# Altium schematic sheet main.SchDoc(main)
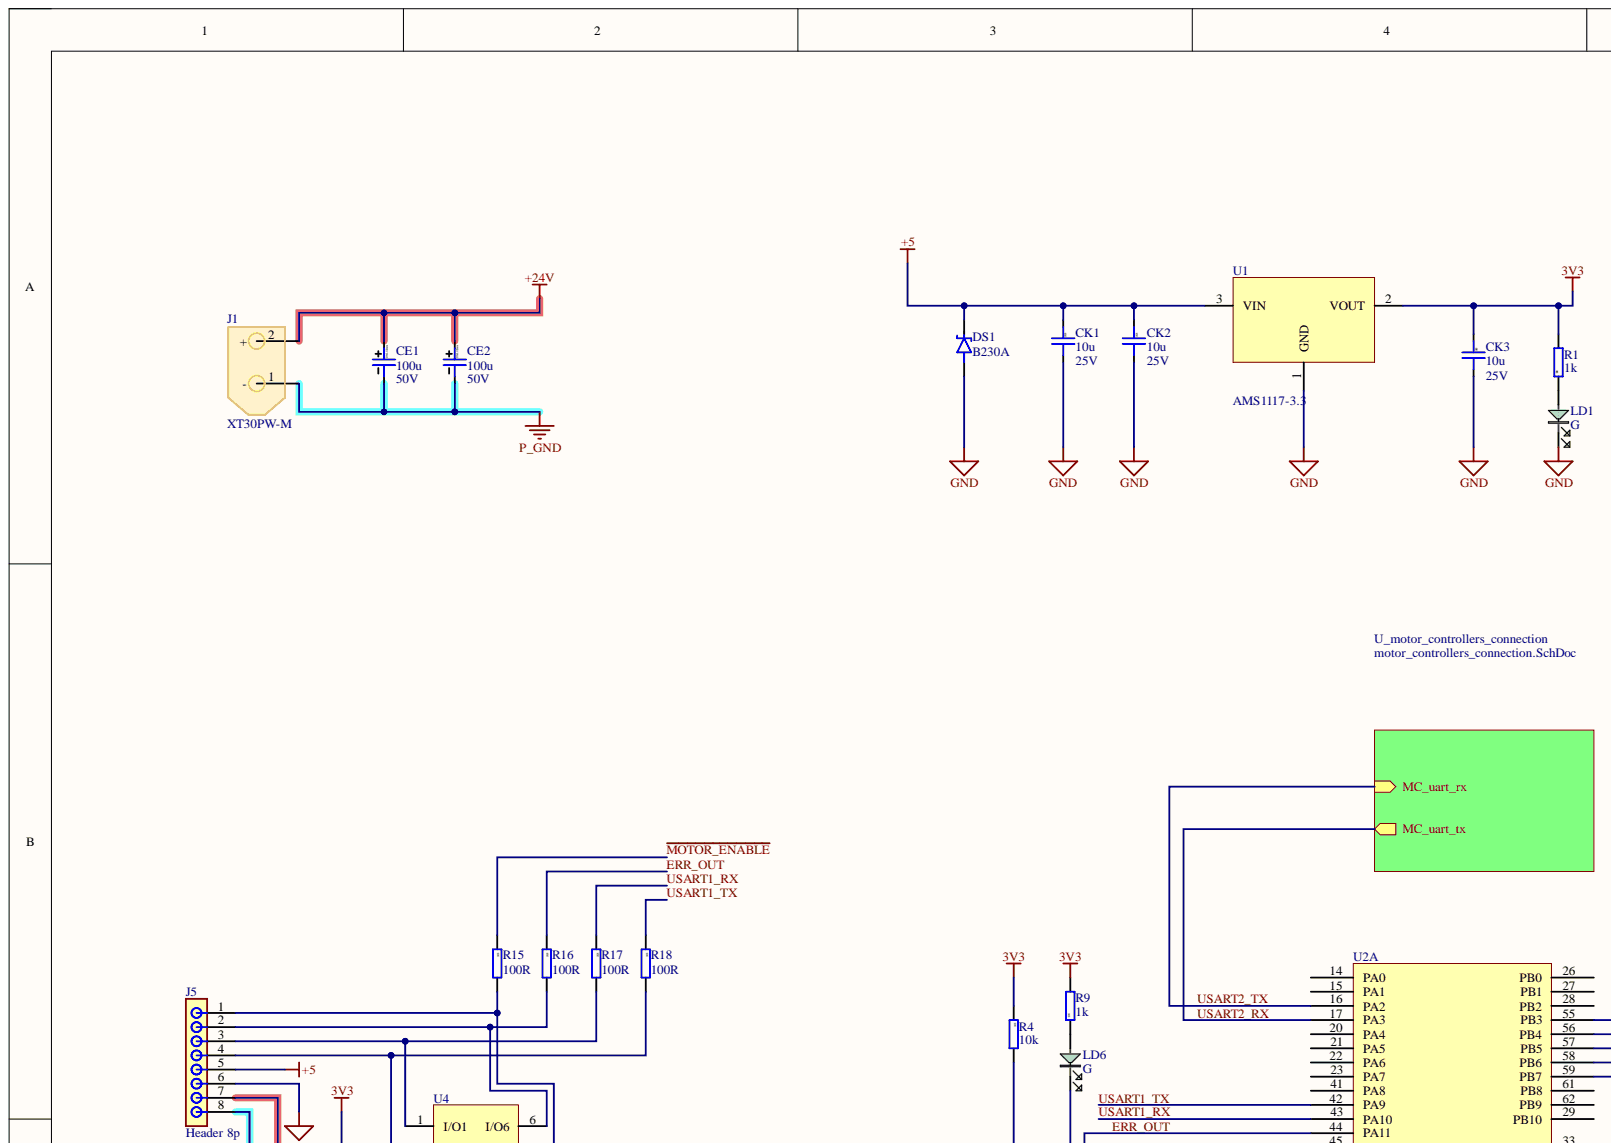
[diagram p0: main.SchDoc, top left]
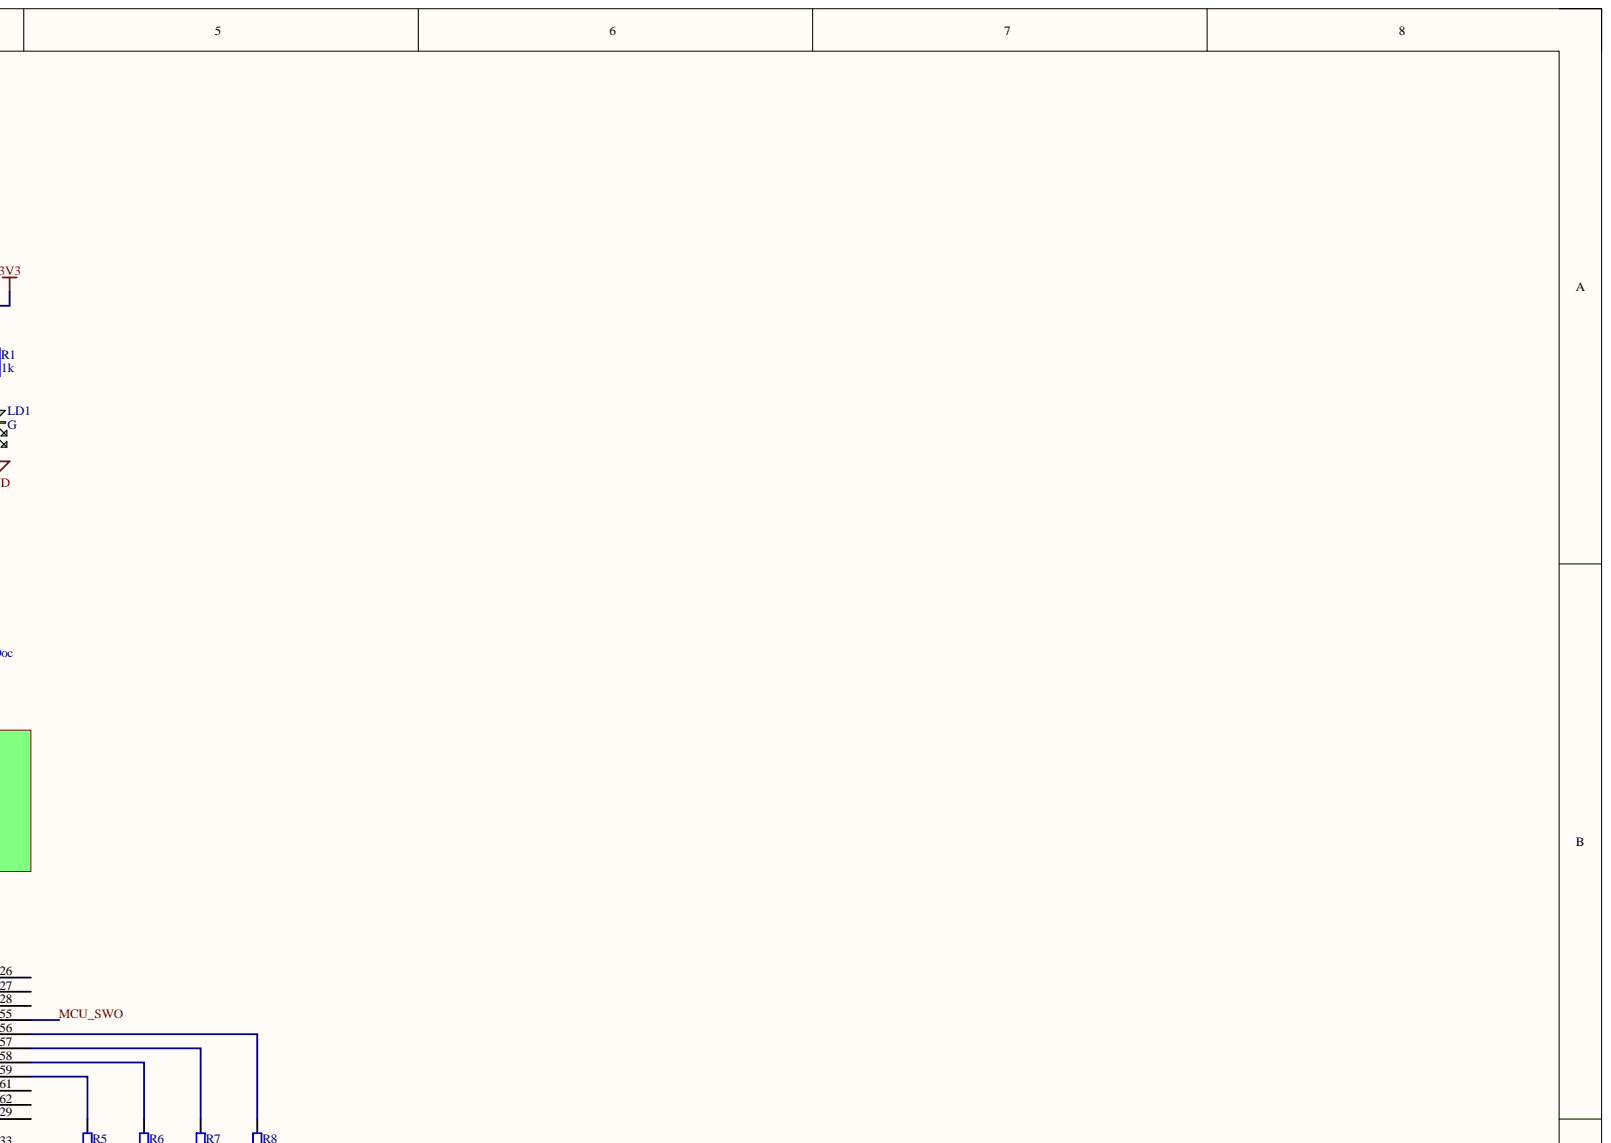
[diagram p1: main.SchDoc, top right]
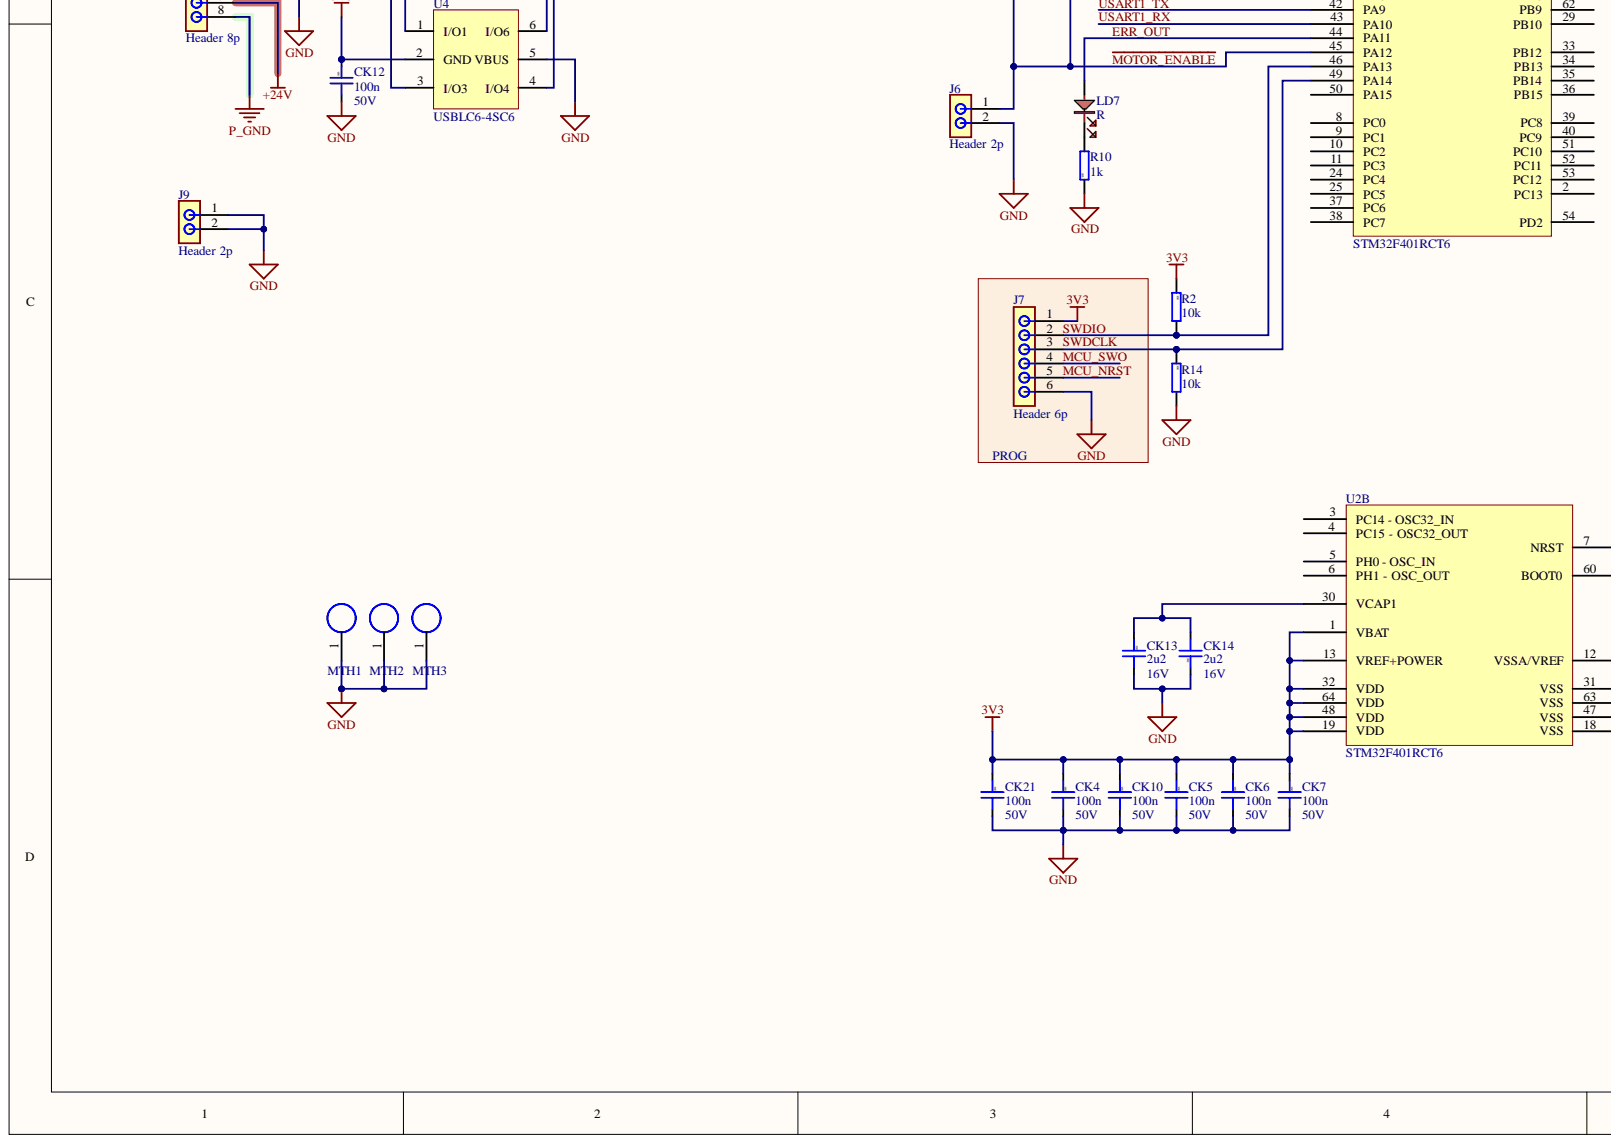
[diagram p2: main.SchDoc, bottom left]
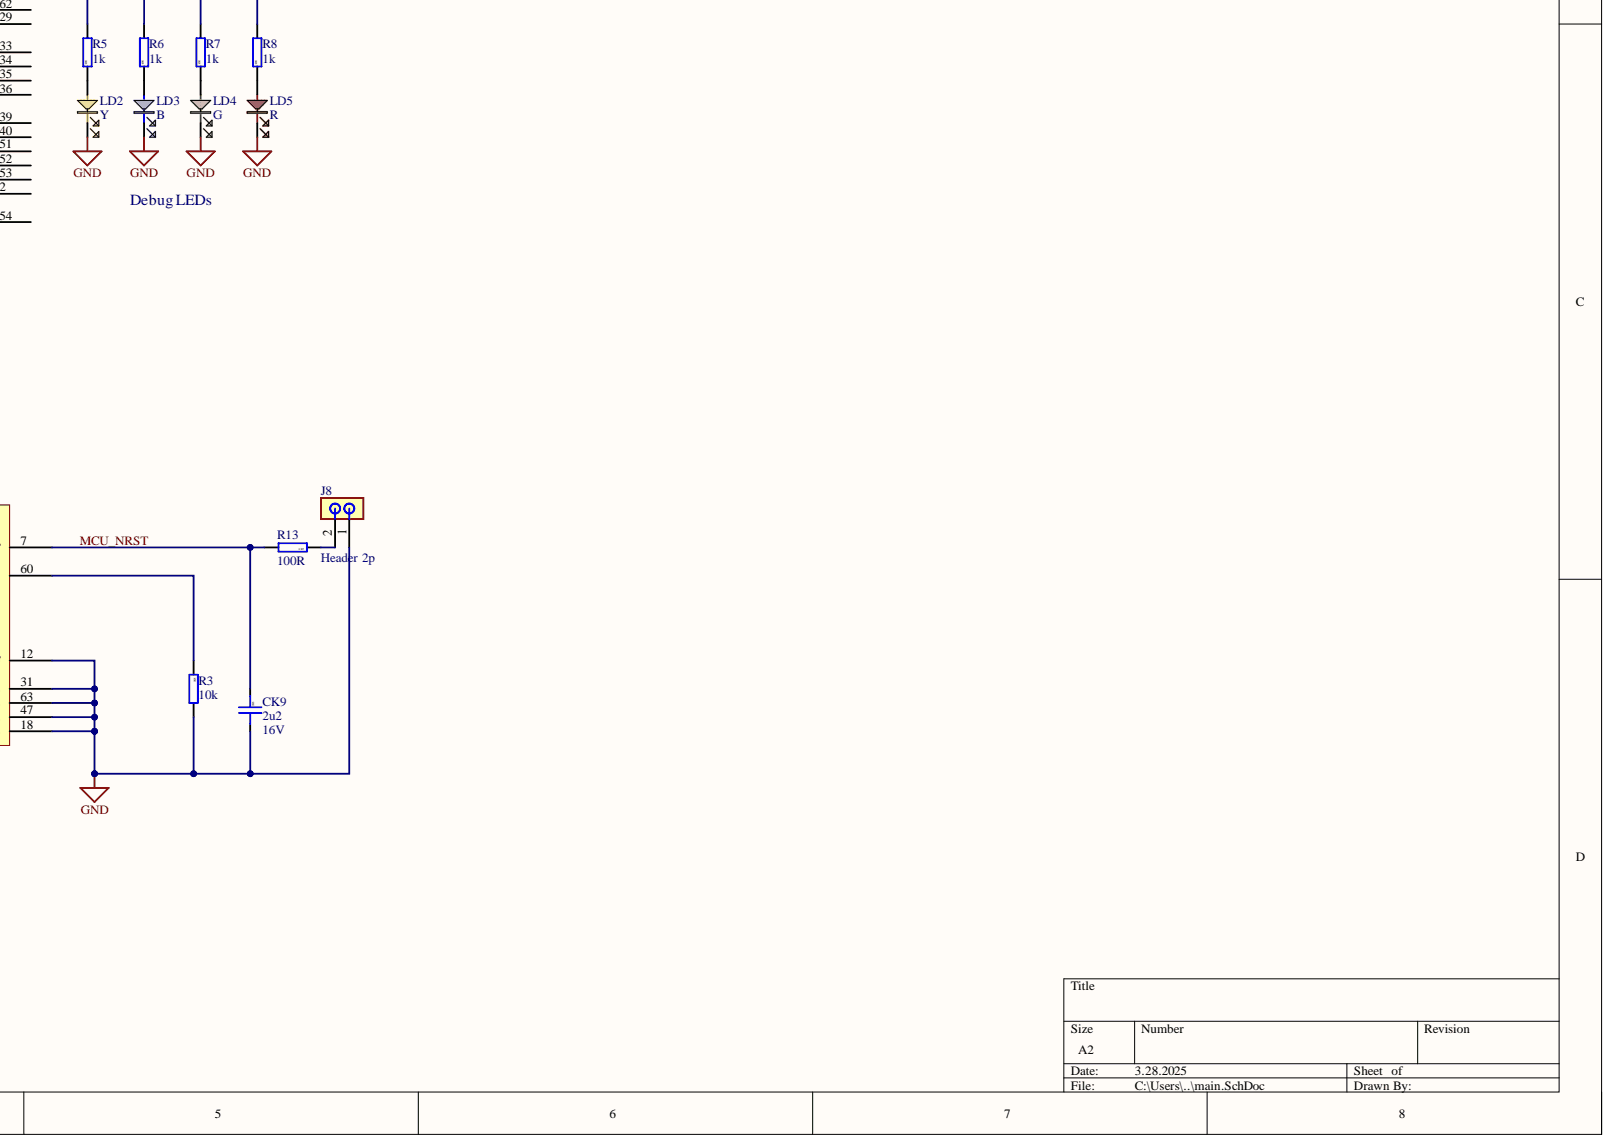
[diagram p3: main.SchDoc, bottom right]

## Parts
CE1  100u  Voltage rating: 50V  [CAPAE830X1100N]
CE2  100u  Voltage rating: 50V  [CAPAE830X1100N]
CK1  10u  Voltage rating: 25V  [CAP0805]
CK2  10u  Voltage rating: 25V  [CAP0805]
CK3  10u  Voltage rating: 25V  [CAP0805]
CK4  100n  Voltage rating: 50V  [CAP0603]
CK5  100n  Voltage rating: 50V  [CAP0603]
CK6  100n  Voltage rating: 50V  [CAP0603]
CK7  100n  Voltage rating: 50V  [CAP0603]
CK9  2u2  Voltage rating: 16V  [CAP0603]
CK10  100n  Voltage rating: 50V  [CAP0603]
CK12  100n  Voltage rating: 50V  [CAP0603]
CK13  2u2  Voltage rating: 16V  [CAP0603]
CK14  2u2  Voltage rating: 16V  [CAP0603]
CK21  100n  Voltage rating: 50V  [CAP0603]
DS1  B230A  [DIOM4326X23M]
J1  XT30PW-M  [XT30PWM]
J5  Header 8p  [Pin header 8p]
J6  Header 2p  [Pin header 2p]
J7  Header 6p  [Pin header 6p]
J8  Header 2p  [Pin header 2p]
J9  Header 2p  [Pin header 2p]
LD1  G  [0603_LED_G]
LD2  Y  [0603_LED_Y]
LD3  B  [0603_LED_B]
LD4  G  [0603_LED_G]
LD5  R  [0603_LED_R]
LD6  G  [0603_LED_G]
LD7  R  [0603_LED_R]
MTH1  Hole  [3.2/6 MM]  (value not drawn)  (no BOM)
MTH2  Hole  [3.2/6 MM]  (value not drawn)  (no BOM)
MTH3  Hole  [3.2/6 MM]  (value not drawn)  (no BOM)
R1  1k  Package: 0805  [RESC2012X06N(SMR0805)]
R2  10k  Package: 0805  [RESC2012X06N(SMR0805)]
R3  10k  Package: 0805  [RESC2012X06N(SMR0805)]
R4  10k  Package: 0805  [RESC2012X06N(SMR0805)]
R5  1k  Package: 0805  [RESC2012X06N(SMR0805)]
R6  1k  Package: 0805  [RESC2012X06N(SMR0805)]
R7  1k  Package: 0805  [RESC2012X06N(SMR0805)]
R8  1k  Package: 0805  [RESC2012X06N(SMR0805)]
R9  1k  Package: 0805  [RESC2012X06N(SMR0805)]
R10  1k  Package: 0805  [RESC2012X06N(SMR0805)]
R13  100R  [RESC2012X06N(SMR0805)]
R14  10k  Package: 0805  [RESC2012X06N(SMR0805)]
R15  100R  Package: 0805  [RESC2012X06N(SMR0805)]
R16  100R  Package: 0805  [RESC2012X06N(SMR0805)]
R17  100R  Package: 0805  [RESC2012X06N(SMR0805)]
R18  100R  Package: 0805  [RESC2012X06N(SMR0805)]
U1  AMS1117-3.3  [SOT230P700X180-4M]
U2  STM32F401RCT6  [LQFP64]  (parts A,B)
U4  USBLC6-4SC6  [SOT23-6]

## Nets
3V3: CK3.1 CK4.1 CK5.1 CK6.1 CK7.1 CK10.1 CK12.1 CK21.1 J7.1 R1.1 R2.2 R4.2 R9.1 U1.2 U2.1(VBAT) U2.13(VREF+POWER) U2.19(VDD) U2.32(VDD) U2.48(VDD) U2.64(VDD) U4.2(GND)
+5: CK1.1 CK2.1 DS1.1 J5.5 U1.3
+24V: CE1.1 CE2.1 J1.2 J5.7
GND: CK1.2 CK2.2 CK3.2 CK4.2 CK5.2 CK6.2 CK7.2 CK9.2 CK10.2 CK12.2 CK13.2 CK14.1 CK21.2 DS1.2 J5.6 J6.2 J7.6 J8.1 J9.1 J9.2 LD1.2 LD2.2 LD3.2 LD4.2 LD5.2 MTH1.1 MTH2.1 MTH3.1 R3.1 R10.2 R14.1 U1.1 U2.12(VSSA/VREF) U2.18(VSS) U2.31(VSS) U2.47(VSS) U2.63(VSS) U4.5(VBUS)
P_GND: CE1.2 CE2.2 J1.1 J5.8
~{MOTOR_ENABLE}: J6.1 LD6.2 R4.1 R15.2 U2.45(PA12)
ERR_OUT: LD7.1 R16.2 U2.44(PA11)
MCU_NRST: CK9.1 J7.5 R13.1 U2.7(NRST)
MCU_SWO: J7.4 U2.55(PB3)
SWDCLK: J7.3 R14.2 U2.49(PA14)
SWDIO: J7.2 R2.1 U2.46(PA13)
USART1_RX: R17.2 U2.43(PA10)
USART1_TX: R18.2 U2.42(PA9)
USART2_RX: U2.17(PA3)
USART2_TX: U2.16(PA2)
NetCK13_1: CK13.1 CK14.2 U2.30(VCAP1)
NetJ5_1: J5.1 R15.1 U4.4(I/O4)
NetJ5_2: J5.2 R16.1 U4.6(I/O6)
NetJ5_3: J5.3 R17.1 U4.1(I/O1)
NetJ5_4: J5.4 R18.1 U4.3(I/O3)
NetJ8_2: J8.2 R13.2
NetLD1_1: LD1.1 R1.2
NetLD2_1: LD2.1 R5.2
NetLD3_1: LD3.1 R6.2
NetLD4_1: LD4.1 R7.2
NetLD5_1: LD5.1 R8.2
NetLD6_1: LD6.1 R9.2
NetLD7_2: LD7.2 R10.1
NetR3_2: R3.2 U2.60(BOOT0)
NetR5_1: R5.1 U2.59(PB7)
NetR6_1: R6.1 U2.58(PB6)
NetR7_1: R7.1 U2.57(PB5)
NetR8_1: R8.1 U2.56(PB4)
NetU2_2: U2.2(PC13)
NetU2_3: U2.3(PC14_-_OSC32_IN)
NetU2_4: U2.4(PC15_-_OSC32_OUT)
NetU2_5: U2.5(PH0_-_OSC_IN)
NetU2_6: U2.6(PH1_-_OSC_OUT)
NetU2_8: U2.8(PC0)
NetU2_9: U2.9(PC1)
NetU2_10: U2.10(PC2)
NetU2_11: U2.11(PC3)
NetU2_14: U2.14(PA0)
NetU2_15: U2.15(PA1)
NetU2_20: U2.20(PA4)
NetU2_21: U2.21(PA5)
NetU2_22: U2.22(PA6)
NetU2_23: U2.23(PA7)
NetU2_24: U2.24(PC4)
NetU2_25: U2.25(PC5)
NetU2_26: U2.26(PB0)
NetU2_27: U2.27(PB1)
NetU2_28: U2.28(PB2)
NetU2_29: U2.29(PB10)
NetU2_33: U2.33(PB12)
NetU2_34: U2.34(PB13)
NetU2_35: U2.35(PB14)
NetU2_36: U2.36(PB15)
NetU2_37: U2.37(PC6)
NetU2_38: U2.38(PC7)
NetU2_39: U2.39(PC8)
NetU2_40: U2.40(PC9)
NetU2_41: U2.41(PA8)
NetU2_50: U2.50(PA15)
NetU2_51: U2.51(PC10)
NetU2_52: U2.52(PC11)
NetU2_53: U2.53(PC12)
NetU2_54: U2.54(PD2)
NetU2_61: U2.61(PB8)
NetU2_62: U2.62(PB9)

## Library data of the parts
CE1 CE2 = Cap el 100u 50V: 100uF 50V ±20% SMD,D8xL10.2mm Aluminum Electrolytic Capacitors - SMD ROHS; Basic: No; Component Kind: Standard; JLCPCB Part: C3352; TME Part: CK1H107M08010VR; Value: 100u; Library Name: Kubikova ultra knihovna.SchLib
CK1-CK3 = Cap 10u 0805: 25V 10uF X5R ±10% 0805 Multilayer Ceramic Capacitors MLCC - SMD/SMT ROHS; Basic: Yes; Component Kind: Standard; JLCPCB Part: C15850; Value: 10u; Library Name: Kubikova ultra knihovna.SchLib
CK4-CK7 CK10 CK12 CK21 = Cap 100n 0603: 50V 100nF X7R ±10% 0603 Multilayer Ceramic Capacitors MLCC - SMD/SMT ROHS; Basic: Yes; Component Kind: Standard; JLCPCB Part: C14663; Value: 100n; Library Name: Kubikova ultra knihovna.SchLib
CK9 CK13 CK14 = Cap 2u2 0603: 16V 2.2uF X5R ±10% 0603 Multilayer Ceramic Capacitors MLCC - SMD/SMT ROHS; Basic: Yes; Component Kind: Standard; JLCPCB Part: C23630; Value: 2u2; Library Name: Kubikova ultra knihovna.SchLib
DS1 = B230A-13-F: 30V 500mV@2A 2A SMA(DO-214AC) Schottky Diodes ROHS; Component Kind: Standard; JLCPCB Part: C12889; Library Name: Kubikova ultra knihovna.SchLib
J1 = XT30 male: plug; Basic: No; Current: 15A; Component Kind: Standard; ComponentLink1Description: New link; ComponentLink1URL: https://www.tme.eu/cz/details/xt30pw-m/konektory-dc/amass/; JLCPCB Part: C431092; Library Name: Kubikova ultra knihovna.SchLib
J5 = Pin header 8p: 3A Direct Insert Policy 6P -40℃~+105℃ 2.54mm Single Row Black Brass 1x6P Plugin,P=2.54mm Pin Headers ROHS; Manufacturer_Name: Connfly; Manufacturer_Part_Number: DS1021-1*2SF11-B; Component Kind: Standard; Datasheet Link: https://www.tme.com/Document/cc301e58a5da78cb543a94b5a5b7f06a/DS1021.pdf; Height: 5.88mm; JLCPCB Part: C37208; Library Name: Kubikova ultra knihovna.SchLib
J6 J8 J9 = Pin header 2p: Connector; Manufacturer_Name: Connfly; Manufacturer_Part_Number: DS1021-1*2SF11-B; Basic: No; Component Kind: Standard; Datasheet Link: https://www.tme.com/Document/cc301e58a5da78cb543a94b5a5b7f06a/DS1021.pdf; Height: 5.88mm; JLCPCB Part: C492401; Library Name: Kubikova ultra knihovna.SchLib
J7 = Pin header 6p: 3A Direct Insert Policy 6P -40℃~+105℃ 2.54mm Single Row Black Brass 1x6P Plugin,P=2.54mm Pin Headers ROHS; Manufacturer_Name: Connfly; Manufacturer_Part_Number: DS1021-1*2SF11-B; Component Kind: Standard; Datasheet Link: https://www.tme.com/Document/cc301e58a5da78cb543a94b5a5b7f06a/DS1021.pdf; Height: 5.88mm; JLCPCB Part: C37208; Library Name: Kubikova ultra knihovna.SchLib
LD1 LD4 LD6 = LED G 0603: 0603 Green Led; Component Kind: Standard; JLCPCB Part: C22371297; Library Name: Kubikova ultra knihovna.SchLib
LD2 = LED Y 0603: 0603 Yellow Led; Component Kind: Standard; JLCPCB Part: C7496811; Library Name: Kubikova ultra knihovna.SchLib
LD3 = LED B 0603: 0603 Blue Led; Component Kind: Standard; JLCPCB Part: C7496819; Library Name: Kubikova ultra knihovna.SchLib
LD5 LD7 = LED R 0603: -40℃~+85℃ Red 0603 LED Indication - Discrete ROHS; Component Kind: Standard; JLCPCB Part: C2286; Library Name: Kubikova ultra knihovna.SchLib
MTH1-MTH3 = Hole: Universal mounting hole plated; Library Name: Kubikova ultra knihovna.SchLib
R1 R5-R10 = Res 1k 0805: 125mW Thick Film Resistors 150V ±100ppm/℃ ±1% 1kΩ 0805 Chip Resistor - Surface Mount ROHS; Basic: Yes; Tolerance & Rating: 1%/0.1W; Component Kind: Standard; ComponentLink3Description: rezistor čip; ComponentLink3URL: heliosgreen://v1/hegMT/5-MT/Classes(343)/Folders/OpenForm?RecordNumbers=[381513]; JLCPCB Part: C17513; Simulation: Simple; SMD: 1; Value: 1k; Author: JP/27.03.2014; Library Name: Kubikova ultra knihovna.SchLib
R2-R4 R14 = Res 10k 0805: 125mW Thick Film Resistors 150V ±100ppm/℃ ±1% 10kΩ 0805 Chip Resistor - Surface Mount ROHS; Basic: Yes; Tolerance & Rating: 1%/0.1W; Component Kind: Standard; ComponentLink3Description: rezistor čip; ComponentLink3URL: heliosgreen://v1/hegMT/5-MT/Classes(343)/Folders/OpenForm?RecordNumbers=[381513]; JLCPCB Part: C17414; Simulation: Simple; SMD: 1; Value: 10k; Library Name: Kubikova ultra knihovna.SchLib
R13 R15-R18 = Res 100R 0805: 125mW Thick Film Resistors 150V ±100ppm/℃ ±1% 100Ω 0805 Chip Resistor - Surface Mount ROHS; Basic: Yes; Tolerance & Rating: 1%/0.1W; Component Kind: Standard; ComponentLink3Description: rezistor čip; ComponentLink3URL: heliosgreen://v1/hegMT/5-MT/Classes(343)/Folders/OpenForm?RecordNumbers=[381513]; JLCPCB Part: C17408; Simulation: Simple; SMD: 1; Value: 100R; Author: JP/27.03.2014; Library Name: Kubikova ultra knihovna.SchLib
  R13: Package: 0805
U1 = AMS1117-3.3: 72dB@(120Hz) 1A Fixed 3.3V Positive electrode SOT-223 Voltage Regulators - Linear, Low Drop Out (LDO) Regulators ROHS; Basic: Yes; Component Kind: Standard; JLCPCB Part: C6186; Library Name: Kubikova ultra knihovna.SchLib
U2 = STM32F401RCT6: 256KB 1.7V~3.6V ARM-M4 64KB 84MHz FLASH 50 LQFP-64(10x10) Microcontrollers (MCU/MPU/SOC) ROHS; Basic: No; Component Kind: Standard; JLCPCB Part: C74524; Library Name: Kubikova ultra knihovna.SchLib
U4 = USBLC6-4SC6: 5A 17V 6V Unidirectional 5.25V SOT-23-6 ESD and Surge Protection (TVS/ESD) ROHS; Basic: No; Component Kind: Standard; JLCPCB Part: C111212; Library Name: Kubikova ultra knihovna.SchLib
